# Altium schematic sheet 06_CANBUS.SchDoc(06_CANBUS)
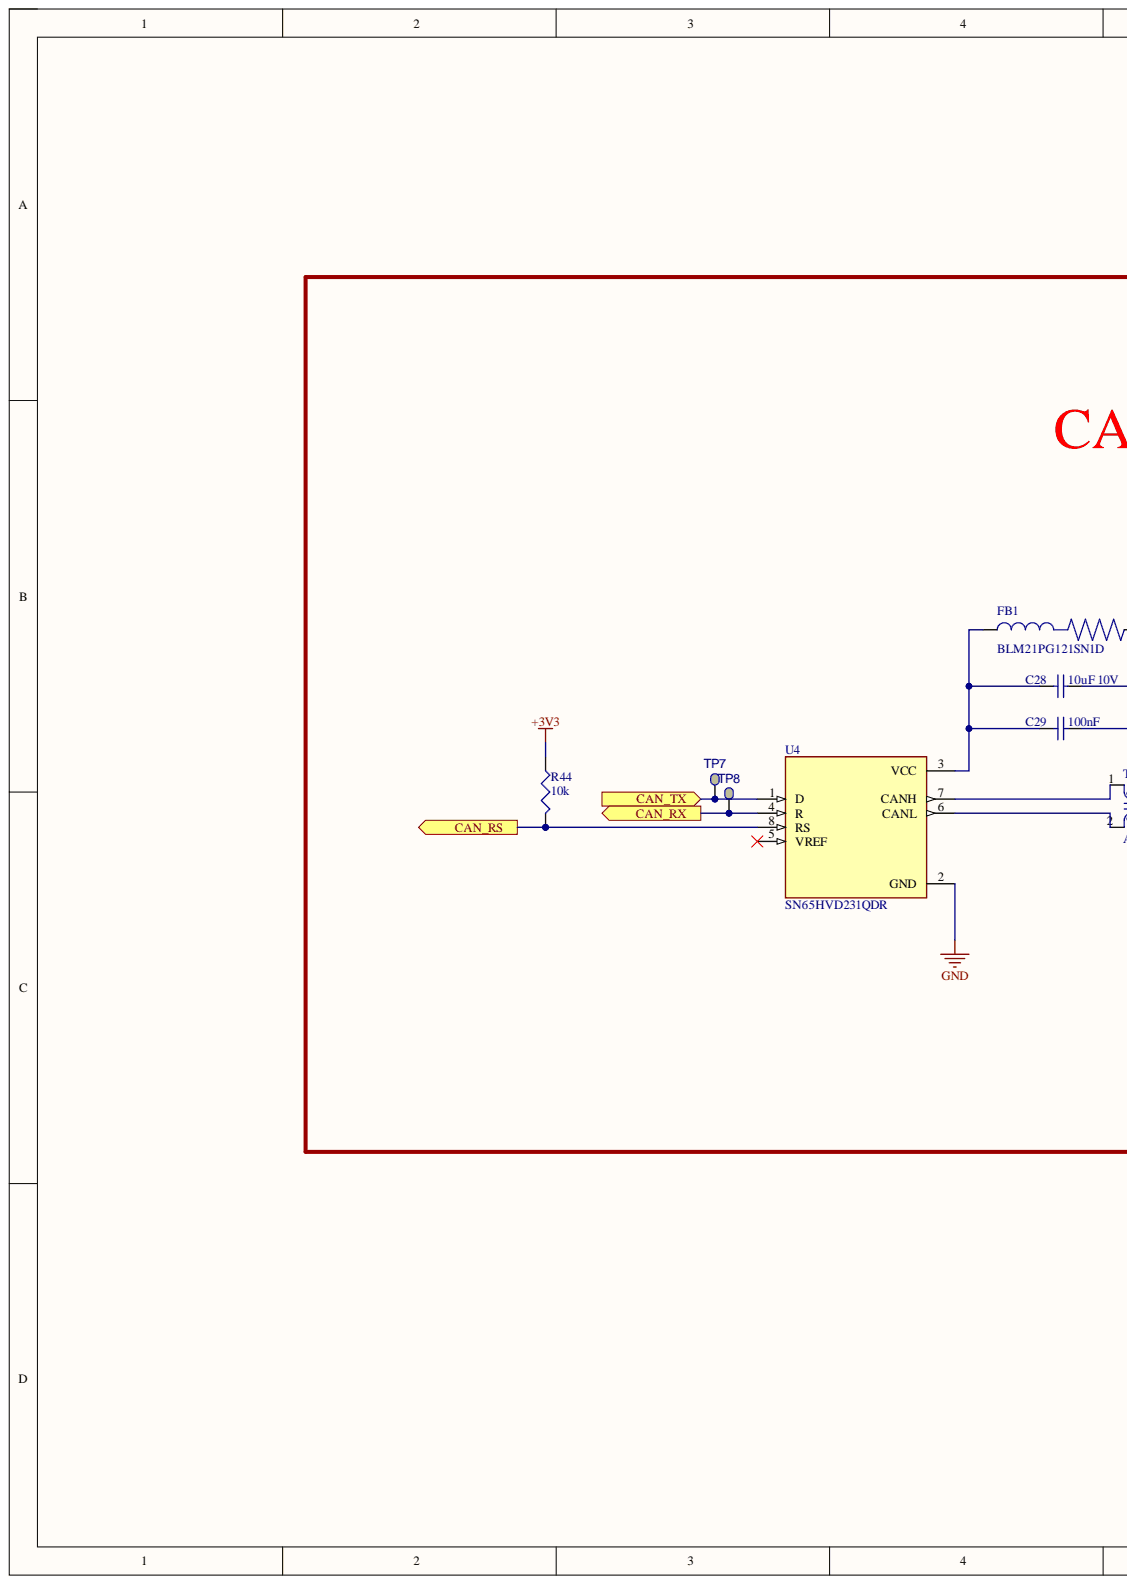
[diagram p0: 06_CANBUS.SchDoc, left]
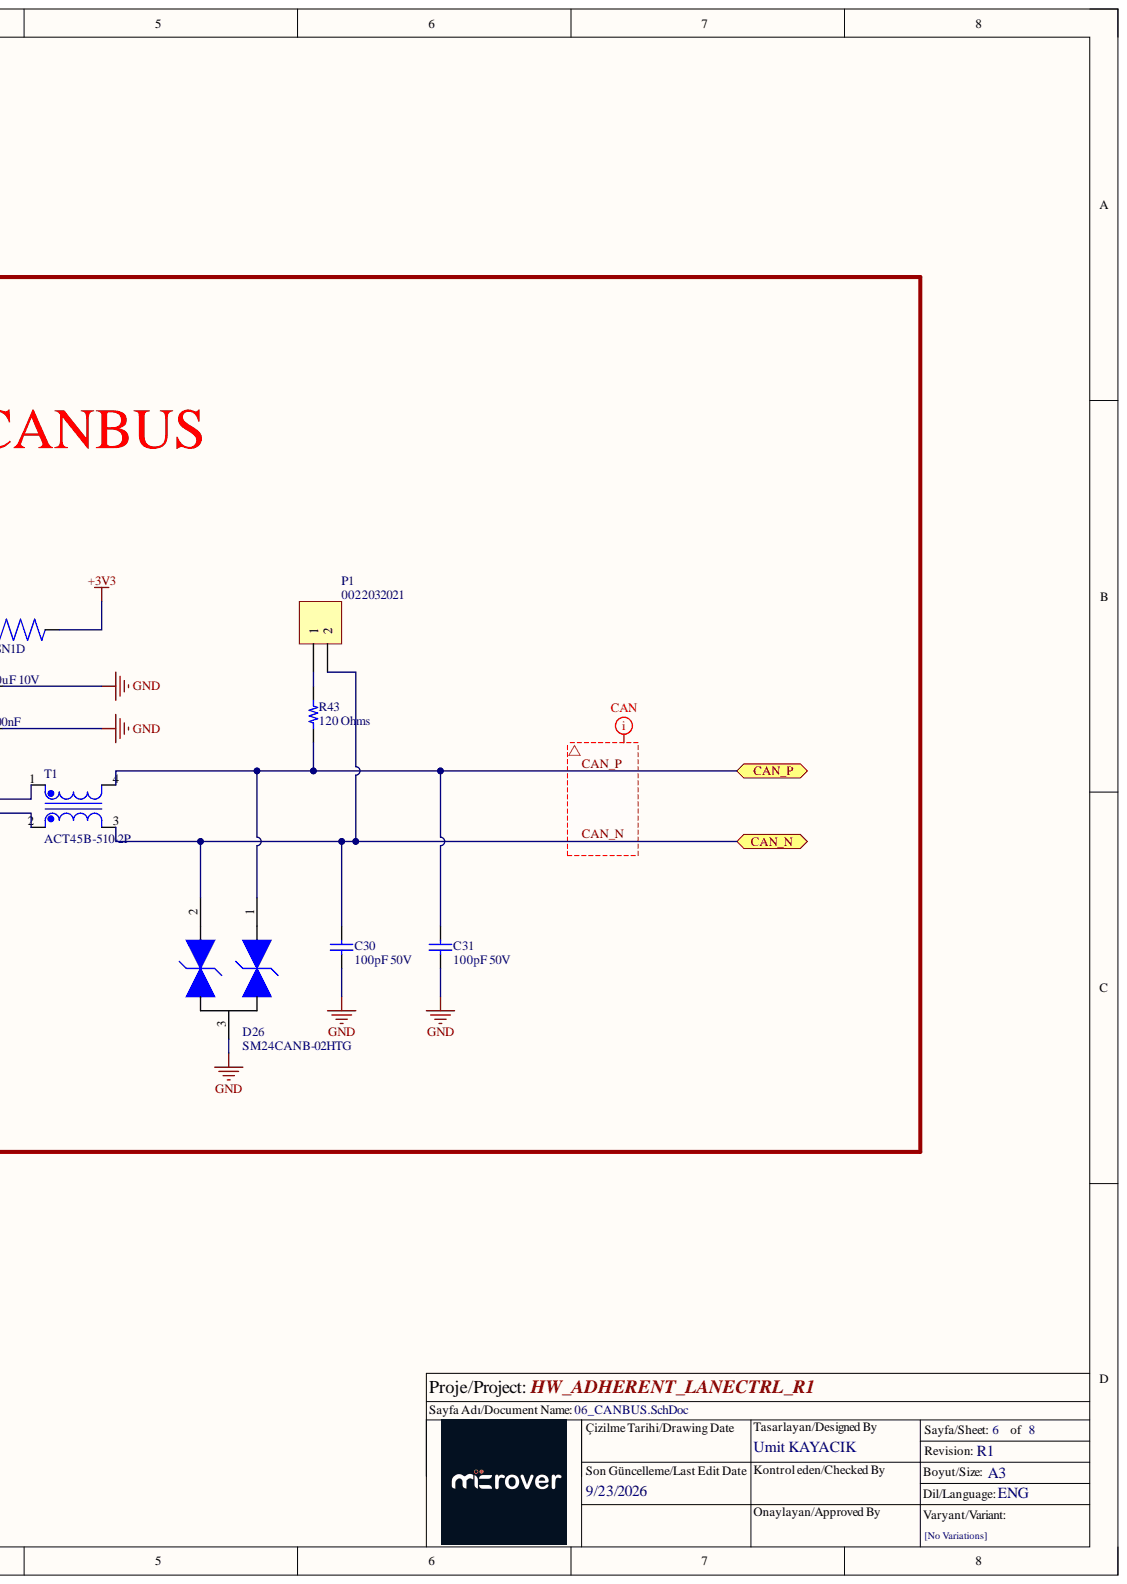
[diagram p1: 06_CANBUS.SchDoc, right]

## Parts
C28  value 10uF 10V  [SMC0603]  (hidden comment: CAP CER 10UF 10V X5R 0603)
C29  100nF  [CAP0603]
C30  value 100pF 50V  [CAP0603]  (hidden comment: CAP CER 100PF 50V C0G/NP0 0603)
C31  value 100pF 50V  [CAP0603]  (hidden comment: CAP CER 100PF 50V C0G/NP0 0603)
D26  LCSC Manufacturer Part Number: SM24CANB-02HTG  [SOT23_3-DIODE]  (hidden comment: CAN)
FB1  BLM21PG121SN1D  [BEADC2012X105N/]
P1  0022032021  [THD 1x2p. male straight]
R43  120 Ohms  [RES 1206_3216]
R44  value 10k  [RES0603]  (hidden comment: RES 10K OHM 1% 1/8W 0603)
T1  ACT45B-510-2P  [TDK_ACT45B-510-2P-TL003_0]
TP7  Testpoint  [SMD_Ø1.5mm]  (value not drawn)  (no BOM)
TP8  Testpoint  [SMD_Ø1.5mm]  (value not drawn)  (no BOM)
U4  SN65HVD231QDR  [SOIC127P599X175-8N/]

## Ports
CAN1_RX, CAN1_TX, CAN_N, CAN_P, CAN_RS

## Nets
+3V3: FB1.2 R44.1
GND: C28.1 C29.1 C30.1 C31.1 D26.3 U4.2(GND)
CAN1_RX: TP8.1 U4.4(R) ; port CAN1_RX
CAN1_TX: TP7.1 U4.1(D) ; port CAN1_TX
CAN_N: C30.2 D26.2 P1.2 T1.3 ; port CAN_N
CAN_P: C31.2 D26.1 R43.1 T1.4 ; port CAN_P
CAN_RS: R44.2 U4.8(RS) ; port CAN_RS
NetC28_2: C28.2 C29.2 FB1.1 U4.3(VCC)
NetP1_1: P1.1 R43.2
NetT1_1: T1.1 U4.7(CANH)
NetT1_2: T1.2 U4.6(CANL)

Not connected: U4 5(VREF)

## Library data of the parts
C28 = CAP0603_10UF_10V: 10 µF ±10% 10V Ceramic Capacitor X5R 0603 (1608 Metric); LCSC Manufacturer Part Number: CL10A106KP8NNNC; Order Code: DIGI: 1276-1192-2-ND; Component Kind: Standard; LCSC Part Number: C19702; Manufacturer Part Number: CL10A106KP8NNNC; Library Name: HW_ADHERENT_LANECTRL_R1.SchLib
C29 = CAP0603_100nF_50V: 0.1 µF ±10% 50V Ceramic Capacitor X7R 0603 (1608 Metric); Manufacturer Name: KEMET; Manufacturer Part Number: C0603C104K5RAC7867; Component Kind: Standard; Datasheet Link: https://componentsearchengine.com/Datasheets/1/06031A100FAT2A.pdf; Height: 0.9mm; Library Name: HW_ADHERENT_LANECTRL_R1.SchLib
C30 C31 = CAP0603_100PF: 100 pF ±5% 50V Ceramic Capacitor C0G, NP0 0603 (1608 Metric); Manufacturer Part Number: CL10C101JB8NNNC; Component Kind: Standard; LCSC Part Number: C14858; Library Name: HW_ADHERENT_LANECTRL_R1.SchLib
D26 = SM24CANB-02HTG_TVS: TVS DIODE 24VWM 50VC SOT23-3; Order Code: DIGI : F6707CT-ND; Value: 2Ch; Component Kind: Standard; LCSC Part Number: C151237; Manufacturer Part Number: SM24CANB-02HTG; Library Name: HW_ADHERENT_LANECTRL_R1.SchLib
FB1 = BLM21PG121SN1D: 120 Ohms @ 100 MHz 1 Power Line Ferrite Bead 0805 (2012 Metric) 3A 30mOhm; Component Kind: Standard; MPN: BLM21PG121SN1D; Supplier: Digi; 490-5986-6-ND; Library Name: HW_ADHERENT_LANECTRL_R1.SchLib
P1 = Header 2: CONN HEADER VERT 2POS 2.54MM; Manufacturer: Molex; Component Kind: Standard; Manufacturer Part Number: 0022032021; Signal Integrity: Connector; Supplier Part Number: WM4000-ND; Library Name: HW_ADHERENT_LANECTRL_R1.SchLib
R43 = AC1206FR-07120RL: RES SMD 120 OHM 1% 1/4W 1206; Manufacturer: Yageo; Power (Watts): 0.25W, 1/4W; Temperature Coefficient: ±100ppm/°C; Component Kind: Standard; ComponentLink1Description: Datasheet; ComponentLink1URL: https://www.yageo.com/upload/media/product/productsearch/datasheet/rchip/PYu-AC_51_RoHS_L_7.pdf; ComponentLink2Description: DigiKey Link; ComponentLink2URL: https://www.digikey.com/en/products/detail/yageo/AC1206FR-07120RL/5897228; Composition: Thick Film; Device Package: 1206 (3216 Metric); Features: Automotive AEC-Q200, Moisture Resistant; Height - Seated (Max): 0.026" (0.65mm); LastUpdated: 2/9/2024 8:54:27 PM; Lifecycle Status: Active; Minimum Order: 1; Number of Terminations: 2; Operating Temperature: -55°C ~ 155°C; Package / Case: 1206 (3216 Metric); Packaging: TapeAndReel; Part Number: AC1206FR-07120RL; Part Status: Active; PartId: 31141; Price: 0.01; Ratings: AEC-Q200; Resistance: 120 Ohms; Series: AC; Size / Dimension: 0.122" L x 0.063" W (3.10mm x 1.60mm); Supplier 1: DigiKey; Supplier Device Package: 1206; Supplier Part Number 1: YAG3810CT-ND; Tolerance: ±1%; Value: 120 Ohms; Library Name: HW_ADHERENT_LANECTRL_R1.SchLib
R44 = RES0603_10K_%1_1: 10 kOhms ±1% 0.125W, 1/8W Chip Resistor 0603 (1608 Metric) Anti-Sulfur Thin Film; LCSC Manufacturer Part Number: MCT06030C1002FP500; Manufacturer Part Number: RNCP0603FTD10K0; Order Code: Digi: RNCP0603FTD10K0TR-ND; Component Kind: Standard; LCSC Part Number: C161803; Library Name: HW_ADHERENT_LANECTRL_R1.SchLib
T1 = ACT45B-510-2P: Ind Common Mode Filter Wirewound 51uH 2KOhm -30% to 50% 100KHz 200mA 1812 T/R; LCSC Manufacturer Part Number: ACT45B-510-2P-TL003; Manufacturer: TDK; Core Material: Ferrite; DC Resistance (DCR): 1R; Element Configuration: Dual; Impedance: 2.8kR; Insulation Resistance: 10MR; Number of Lines: 2; Self Resonant Frequency: 10MHz; Voltage Rating: 50V; Case Code (Imperial): 1812; Case/Package: 1812; Category: Fixed Inductors; Component Kind: Standard; ComponentLink1Description: https://datasheet.ciiva.com/22726/1649098-22726290.pdf?src-supplier=Element14; ComponentLink1URL: https://datasheet.ciiva.com/22726/1649098-22726290.pdf?src-supplier=Element14; ComponentLink2Description: https://datasheet.ciiva.com/26793/act45b-510-2p-tl003-26793344.pdf?src-supplier=IIIC; ComponentLink2URL: https://datasheet.ciiva.com/26793/act45b-510-2p-tl003-26793344.pdf?src-supplier=IIIC; ComponentLink3Description: https://datasheet.ciiva.com/8498/e9711-act-8498633.pdf?src-supplier=Avnet; ComponentLink3URL: https://datasheet.ciiva.com/8498/e9711-act-8498633.pdf?src-supplier=Avnet; ComponentLink4Description: https://datasheet.ciiva.com/1007/e9711-act-1007931.pdf?src-supplier=Digi-Key; ComponentLink4URL: https://datasheet.ciiva.com/1007/e9711-act-1007931.pdf?src-supplier=Digi-Key; ComponentLink5Description: https://datasheet.ciiva.com/15191/getdatasheetpartid-349822-15191803.pdf?src-supplier=Verical; ComponentLink5URL: https://datasheet.ciiva.com/15191/getdatasheetpartid-349822-15191803.pdf?src-supplier=Verical; ComponentLink6Description: https://datasheet.ciiva.com/1007/e9711-act-1007932.pdf?src-supplier=Digi-Key; ComponentLink6URL: https://datasheet.ciiva.com/1007/e9711-act-1007932.pdf?src-supplier=Digi-Key; ComponentLink7Description: https://datasheet.ciiva.com/1007/e9711-act-1007933.pdf?src-supplier=Digi-Key; ComponentLink7URL: https://datasheet.ciiva.com/1007/e9711-act-1007933.pdf?src-supplier=Digi-Key; ComponentLink8Description: https://datasheet.ciiva.com/7649/1833202-7649183.pdf?src-supplier=Element14; ComponentLink8URL: https://datasheet.ciiva.com/7649/1833202-7649183.pdf?src-supplier=Element14; Current: 200mA; Current Rating: 200mA; DC Current: 200mA; Depth: 3.4mm; Height: 2.8mm; Inductance: 51uH; LCSC Part Number: C76584; Lead Free: Lead Free; Length: 4.7mm; Manufacturer Part Number: ACT45B-510-2P-TL003; Max DC Current: 200mA; Max Operating Temperature: 150°C; Military Standard: Not; Min Operating Temperature: -40°C; Mount: Surface Mount; Number of Pins: 4; Package Quantity: 2000; Packaging: Tape and Reel; Pricing 1: 1=1,95000, 10=1,80000, 25=1,65000, 50=1,41000, 100=1,26000, 250=1,08000, 500=1,02000, 1000=0,93000 (USD); Radiation Hardening: No; REACH SVHC: No SVHC; RoHS: Yes; Stock 1: 75821; Supplier 1: Digi-Key; Supplier Part Number 1: 445-3958-1-ND; Termination: SMD/SMT; Test Frequency: 10MHz; Tolerance: 50%; Voltage Rating (DC): 50V; Width: 3.2mm; Library Name: HW_ADHERENT_LANECTRL_R1.SchLib
TP7 TP8 = TEST PAD SMT 10MIL X 20MIL: Description: Testpoint; DNP: DNP; Value: Testpoint; Library Name: HW_ADHERENT_LANECTRL_R1.SchLib
U4 = SN65HVD231QDR: 3.3-V CAN Transceiver with Sleep Mode; Component Kind: Standard; MPN: SN65HVD231QDR; Supplier: Digi; 296-48950-6-ND; Library Name: HW_ADHERENT_LANECTRL_R1.SchLib
